# Altium schematic sheet FLASH.SchDoc
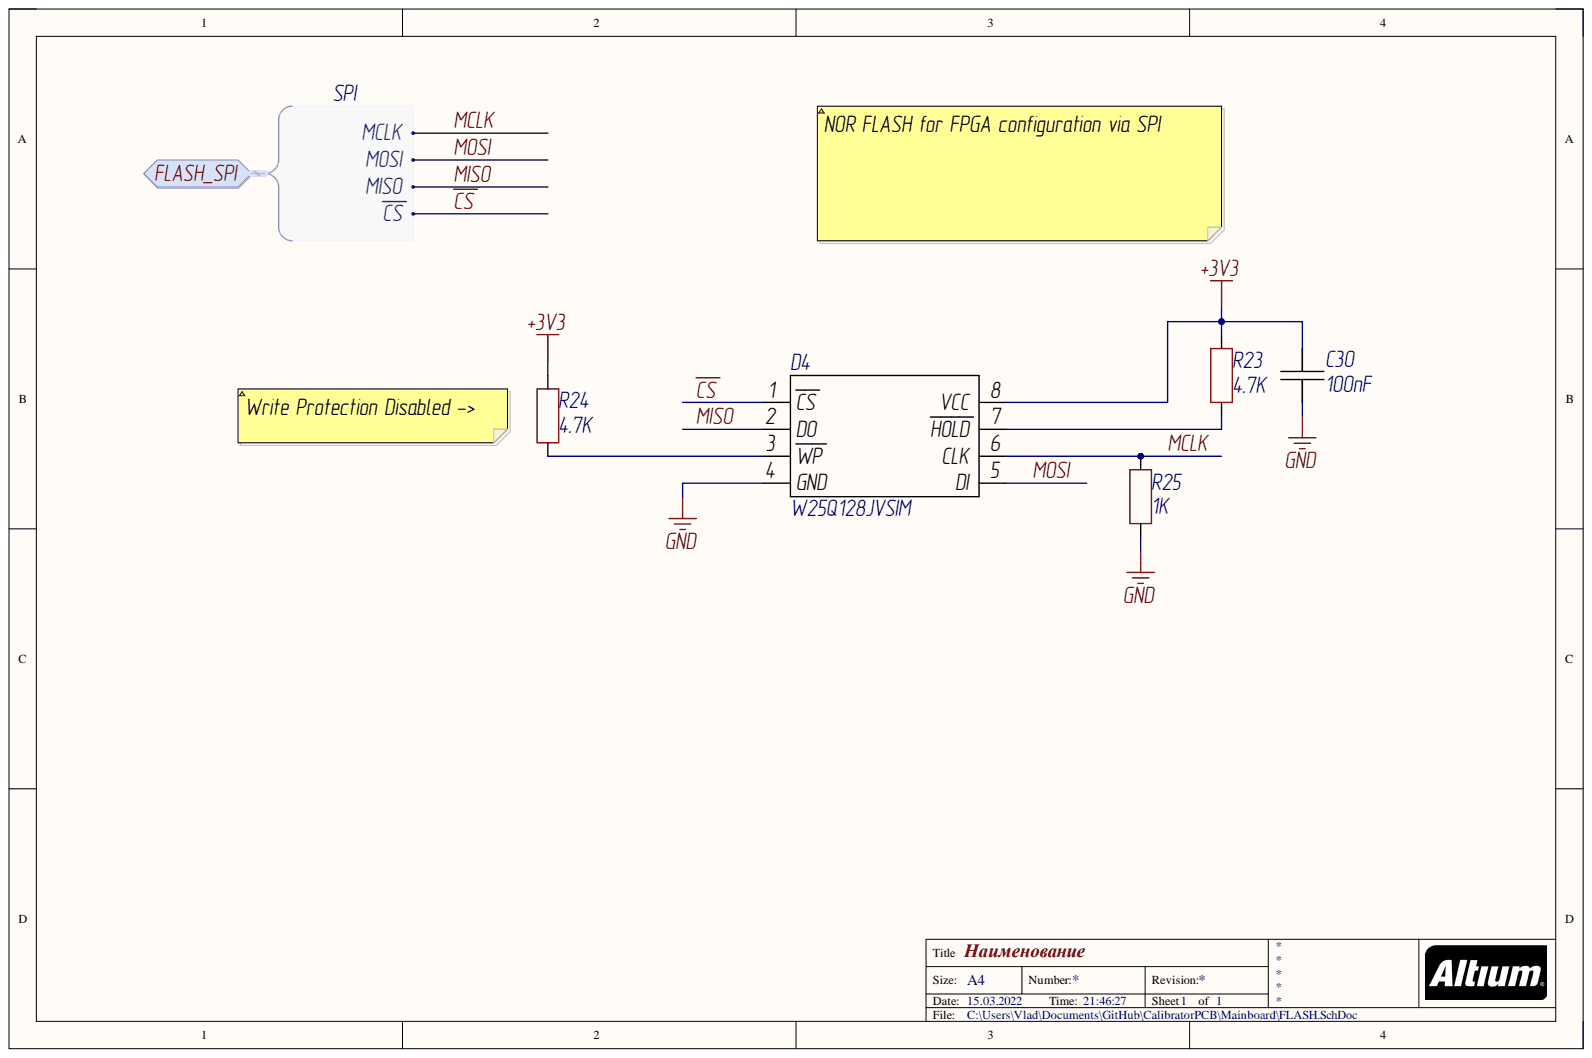
[diagram p0: FLASH.SchDoc]

## Parts
C30  value 100nF  [CAP0402]  (hidden comment: 100nF 50V)
D4  =Value  [SOIC-8_208MIL_M]
R23  value 4.7K  [RESC0402(1005)_M]  (hidden comment: 4.7K 1/16W)
R24  value 4.7K  [RESC0402(1005)_M]  (hidden comment: 4.7K 1/16W)
R25  value 1K  [RESC0402(1005)_M]  (hidden comment: 1K 1/16W)

## Ports
FLASH_SPI, FLASH_SPI.MCLK, FLASH_SPI.MISO, FLASH_SPI.MOSI, FLASH_SPI~{.CS}

## Nets
+3V3: C30.2 D4.8(VCC) R23.1 R24.1
GND: C30.1 D4.4(GND) R25.1
~{CS}: D4.1(CS) ; port FLASH_SPI
MCLK: D4.6(CLK) R25.2 ; port FLASH_SPI
MISO: D4.2(DO) ; port FLASH_SPI
MOSI: D4.5(DI) ; port FLASH_SPI
NetD4_3: D4.3(WP) R24.2
NetD4_7: D4.7(HOLD) R23.2

## Library data of the parts
C30 = CAP0402: Manufacturer: Samsung Electro-Mechanics; ManufacturerAlt: JLCPCB; MPN: CL05B104KB54PNC; MPNAlt: C307331; BOMDescription: SMD CHIP CERAMIC CAPACITOR CAP0402 100nF 10% 50V  CL05B104KB54PNC; Database Table Name: Symbol_SchLib; MntType: SMD; Notes: Basic Part; Tolerance: 10%; CFootprint: CAP0402; ClassName: Capacitor; Component Kind: Standard; ComponentLink1Description: Datasheet; ComponentLink1URL: https://datasheet.lcsc.com/lcsc/1810191222_Samsung-Electro-Mechanics-CL05B104KB54PNC_C307331.pdf; Height: 0.5mm; Library Name: Library.DbLib; MSL: MSL 1; Package: CAP0402; Part Number: CAP0402; PartType: CHIP CERAMIC CAPACITOR; Pins: 2; RoHS: Comply; Voltage: 50V; Weight: 1.500mg
D4 = W25Q128JVSIM: Manufacturer: WINBOND; Database Table Name: Symbol_SchLib; MntType: SMD; BOMDescription: W25Q128JVSIM; CFootprint: SOIC-8_208MIL_M; ClassName: Flash; Component Kind: Standard; ComponentLink1Description: Datasheet; ComponentLink1URL: https://www.winbond.com/resource-files/w25q128jv_dtr%20revc%2003272018%20plus.pdf; Library Name: Library.DbLib; MPN: W25Q128JVSIM; MSL: MSL 1; Package: SO-8; Part Number: W25Q128JVSIM; PartType: Flash; Pins: 8; RoHS: Comply
R23 R24 = RES0402: Manufacturer: UNI-ROYAL; ManufacturerAlt: JLCPCB; MPN: 0402WGF4701TCE; MPNAlt: C25900; BOMDescription: SMD CHIP RESISTOR RESC0402(1005)_M 4.7K 1% 50V 100ppm/C 0402WGF4701TCE; Database Table Name: Symbol_SchLib; MntType: SMD; Notes: Basic Part; TempCoeff: 100ppm/C; Tolerance: 1%; Voltage: 50V; CFootprint: RESC0402(1005)_M; ClassName: Resistor; Component Kind: Standard; ComponentLink1Description: Datasheet; ComponentLink1URL: https://datasheet.lcsc.com/lcsc/1810301132_UNI-ROYAL-Uniroyal-Elec-0402WGF4701TCE_C25900.pdf; Height: 0.35mm; Library Name: Library.DbLib; MSL: MSL 1; Package: RESC0402(1005)_M; Part Number: RES0402; PartType: CHIP RESISTOR; Pins: 2; Power: 1/16W; RoHS: Comply
R25 = RES0402: Manufacturer: UNI-ROYAL; ManufacturerAlt: JLCPCB; MPN: 0402WGF1001TCE; MPNAlt: C11702; BOMDescription: SMD CHIP RESISTOR RESC0402(1005)_M 1K 1% 50V 100ppm/C 0402WGF1001TCE; Database Table Name: Symbol_SchLib; MntType: SMD; TempCoeff: 100ppm/C; Tolerance: 1%; Voltage: 50V; CFootprint: RESC0402(1005)_M; ClassName: Resistor; Component Kind: Standard; ComponentLink1Description: Datasheet; ComponentLink1URL: https://datasheet.lcsc.com/lcsc/1811132311_UNI-ROYAL-Uniroyal-Elec-0402WGF1001TCE_C11702.pdf; Height: 0.35mm; Library Name: Library.DbLib; MSL: MSL 1; Package: RESC0402(1005)_M; Part Number: RES0402; PartType: CHIP RESISTOR; Pins: 2; Power: 1/16W; RoHS: Comply
